# Altium schematic sheet 07_RELAY.SchDoc(07_RELAY)
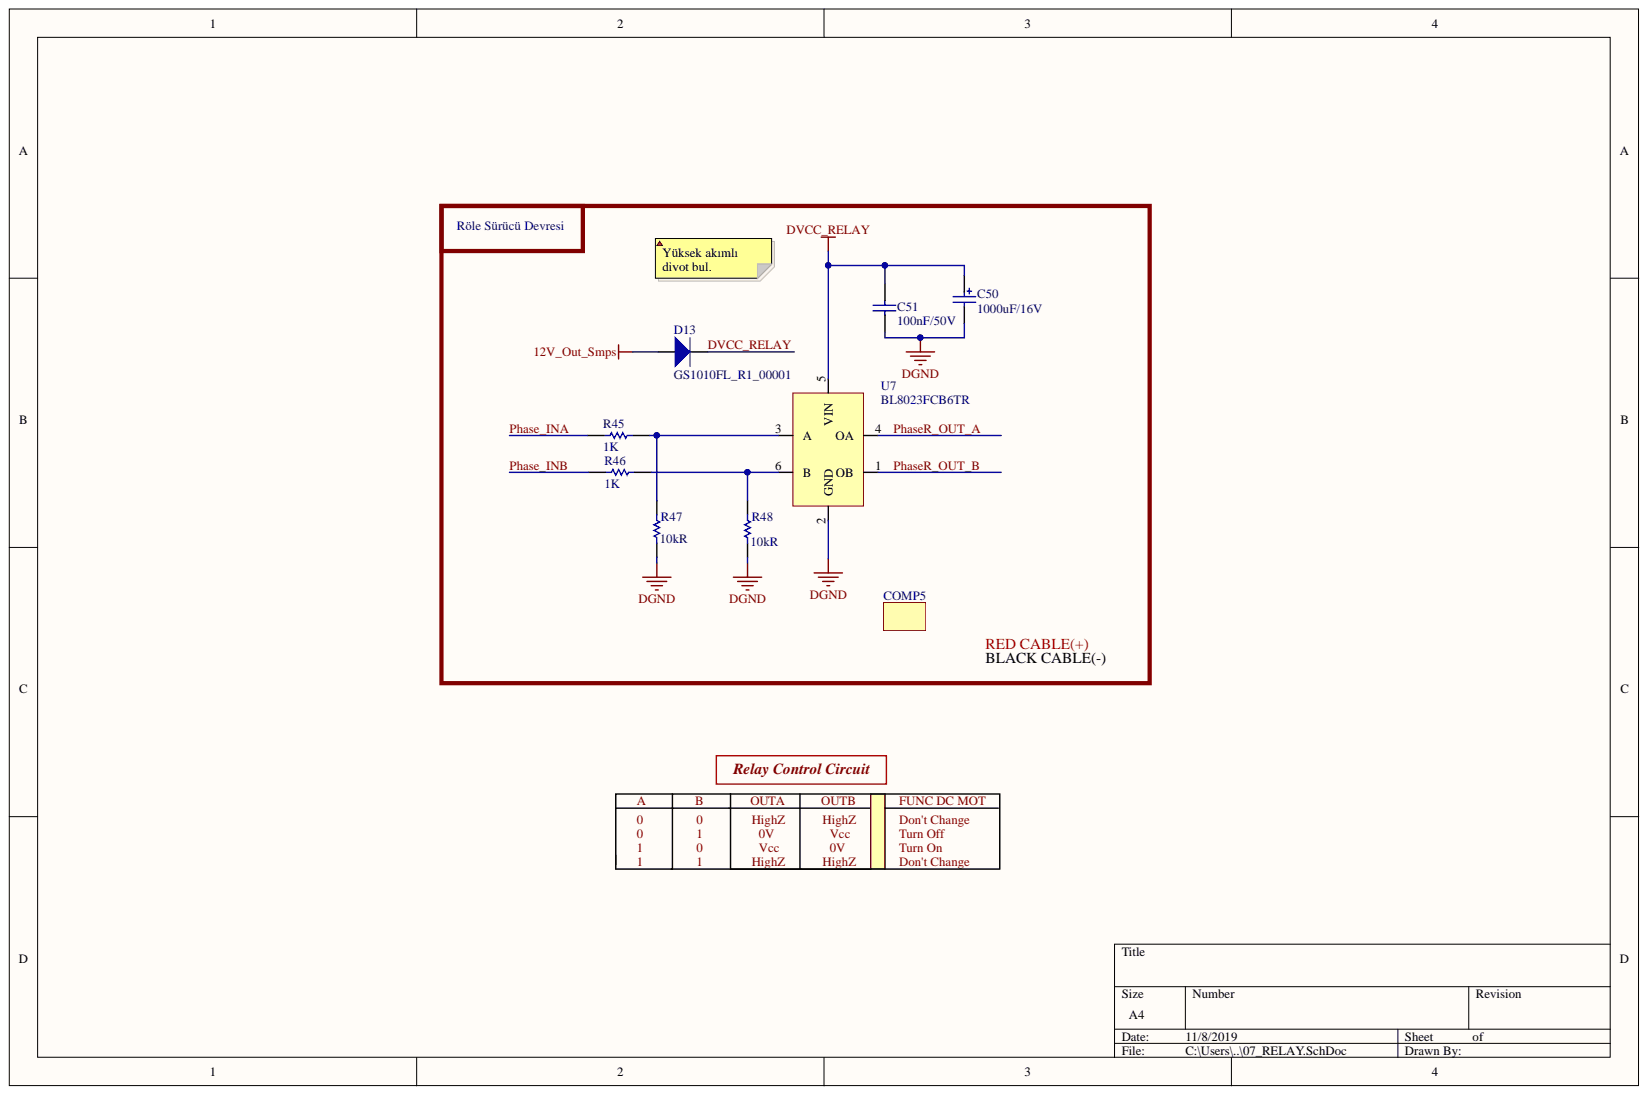
[diagram p0: 07_RELAY.SchDoc]

## Parts
C50  1000uF/16V  [CAP_ALU_ELC_RADIAL_D10_H16.5_P1-05.0_P2-05.0]
C51  100nF/50V  [CAP_0603_V1]
COMP5  PCB  (value not drawn)
D13  GS1010FL_R1_00001  [SOD123 - SOD323]
R45  1K  [RES_0603_V1]
R46  1K  [RES_0603_V1]
R47  Resistance: 10kR  [RES_0805_V1]  (hidden comment: RES_SMD)
R48  Resistance: 10kR  [RES_0805_V1]  (hidden comment: RES_SMD)
U7  BL8023FCB6TR  [SOT23-6]

## Nets
12V_Out_Smps: D13.1
DGND: C50.2 C51.1 R47.1 R48.1 U7.2(GND)
DVCC_RELAY: C50.1 C51.2 D13.2 U7.5(VIN)
Phase_INA: R45.1
Phase_INB: R46.1
PhaseR_OUT_A: U7.4(OA)
PhaseR_OUT_B: U7.1(OB)
NetR45_2: R45.2 R47.2 U7.3(A)
NetR46_2: R46.2 R48.2 U7.6(B)

## Library data of the parts
C50 = ERV1CM102G16OT: Aishi, Miniature Aliminium Electrolytic Capacitor; 048_Manufacturer-2 PN: WL1C108M10020PA; 047_Manufacturer-2: Samwha; 001_Description: Wl 1000UF 16V 20% 10X20/5, 0; Supplier Part Number 1: KEL16337; Supplier 1: Rutronik; Stock 1: 26000; Pricing 1: 500=0.09742, 1000=0.09292, 2000=0.08843, 2500=0.08168, 500=0.1093, 1000=0.1042, 2000=0.0992, 2500=0.0916 (EUR); Library Name: ElectricMeter.SchLib; Component Kind: Standard; 017_Manufacturer-1 PN: ERV1CM102G16OT; 016_Manufacturer-1: Aishi; 004_Value: 1000uF/16V
C51 = CL10B104KB8NNNC: MLCC; 100nF; 50V; X7R; ±10%; SMD; 0603; -55÷125°C; 016_Manufacturer-1: Samsung; Parameter Name: Tape and Reel; Material: Ceramic; 006_SMT/THT: Surface Mount; 001_Description: Capacitor: ceramic; MLCC; 100nF; 50V; X7R; ±10%; SMD; 0603; -55÷125°C; Width: 0.031inch; Voltage Rating (DC): 50V; Voltage Rating: 50V; Voltage: 50V; Tolerance: 10%; Thickness: 0.035inch; Supplier Part Number 2: CL10B104KB8NNNC; Supplier Part Number 1: CL10B104KB8NNNC; Supplier 2: Perfect Parts; Supplier 1: Arrow; Stock 2: 0; Stock 1: 16987458; Radiation Hardening: No; Pricing 1: 4000=0.0047, 8000=0.0043, 12000=0.0032, 1=0.0352, 10=0.0258, 25=0.0233, 100=0.02, 250=0.018 (USD); Package Quantity: 1; Mount: Surface Mount; Min Operating Temperature: -55°C; Max Operating Temperature: 125°C; Library Name: ElectricMeter.SchLib; Length: 1.6mm; Lead Free: Lead Free; Height: 0.8mm; Dielectric: X7R; Depth: 0.8mm; Contact Plating: Tin; ComponentLink3URL: https://produktinfo.conrad.com/datenblaetter/450000-474999/456651-da-01-en-VS_KONDENSATOR_0605.pdf?src-supplier=Conrad; ComponentLink3Description: https://produktinfo.conrad.com/datenblaetter/450000-474999/456651-da-01-en-VS_KONDENSATOR_0605.pdf?src-supplier=Conrad; ComponentLink2URL: https://www.verical.com/server-webapp/html/getDatasheet?partID=89097&src-supplier=Verical; ComponentLink2Description: https://www.verical.com/server-webapp/html/getDatasheet?partID=89097&src-supplier=Verical; ComponentLink1URL: http://www.samsungsem.com/kr/support/product-search/mlcc/CL10B104KB8NNNC.jsp?src-supplier=Digi-Key; ComponentLink1Description: http://www.samsungsem.com/kr/support/product-search/mlcc/CL10B104KB8NNNC.jsp?src-supplier=Digi-Key; Component Kind: Standard; Case Code (Metric): 1608; Case Code (Imperial): 0603; Case/Package: 0603; Capacitance: 100nF; 017_Manufacturer-1 PN: CL10B104KB8NNNC
COMP5 = 00_BOS: BOLTA, BDJ011-120A-009 DC Motor Relay, Red Cable will connect to pin 1 and black cable will connect to pin 2.; Library Name: SCH_LIB.SCHLIB; Component Kind: Standard; 017_Manufacturer-1 PN: BDJ011-120A-009; 016_Manufacturer-1: BOLTA
D13 = DIODE_SMD: DIODE STAND. Single 1A 1000V SMT SOD123FL; 016_Manufacturer-1: Pan Jit; Peak Reverse Current: 1uA; Peak Non-Repetitive Surge Current: 16.5A; Max Repetitive Reverse Voltage (Vrrm): 1kV; 006_SMT/THT: Surface Mount; Supplier Part Number 1: GS1010FL _R1 _00001; Supplier 1: NAC Semi; Stock 1: 295000; Radiation Hardening: No; Pricing 1: 24000=0.0296, 295000=0.0276 (USD); Number of Pins: 2; Min Operating Temperature: -50°C; Max Operating Temperature: 150°C; Library Name: ElectricMeter.SchLib; Forward Current: 1A; Element Configuration: Single; Component Kind: Standard; 017_Manufacturer-1 PN: GS1010FL_R1_00001
R45 R46 = RC0603FR-071KL: RES SMD 1K OHM 1% 1/10W 0603; 016_Manufacturer-1: Yageo; Voltage Rating: 50V; Temperature Coefficient: 100ppm/°C; Resistance: 1kR; Power Rating: 100mW; Parameter Name: Tape and Reel; Tolerance: 1%; Termination: SMD/SMT; Supplier Part Number 1: RC0603FR-07 1KL; Supplier 1: Verical; Stock 1: 0; REACH SVHC: No SVHC; Radiation Hardening: No; Min Operating Temperature: -55°C; Military Standard: Not; Max Operating Temperature: 155°C; Library Name: ElectricMeter.SchLib; Length: 1.6mm; Lead Free: Lead Free; Height: 0.45mm; Depth: 0.8mm; Contact Plating: Matte,Tin; Composition: Thick Film; ComponentLink7URL: http://www.farnell.com/datasheets/443971.pdf?src-supplier=Element14; ComponentLink7Description: http://www.farnell.com/datasheets/443971.pdf?src-supplier=Element14; ComponentLink6URL: https://www.verical.com/server-webapp/html/getDatasheet?partID=404327&src-supplier=Verical; ComponentLink6Description: https://www.verical.com/server-webapp/html/getDatasheet?partID=404327&src-supplier=Verical; ComponentLink5URL: http://www.yageo.com/documents/recent/PYu-RC_Group_51_RoHS_L_2.pdf?src-supplier=Avnet; ComponentLink5Description: http://www.yageo.com/documents/recent/PYu-RC_Group_51_RoHS_L_2.pdf?src-supplier=Avnet; ComponentLink4URL: http://www.farnell.com/datasheets/1868787.pdf?src-supplier=Element14; ComponentLink4Description: http://www.farnell.com/datasheets/1868787.pdf?src-supplier=Element14; ComponentLink3URL: http://www.farnell.com/datasheets/2167052.pdf?src-supplier=Element14; ComponentLink3Description: http://www.farnell.com/datasheets/2167052.pdf?src-supplier=Element14; ComponentLink2URL: http://www.yageo.com/documents/recent/PYu-RC0603_51_RoHS_L_4.pdf?src-supplier=Avnet+Europe; ComponentLink2Description: http://www.yageo.com/documents/recent/PYu-RC0603_51_RoHS_L_4.pdf?src-supplier=Avnet+Europe; ComponentLink1URL: http://documents.rs-components.com/techlib://itc_doclist_GB.htm?stockno=5048928&src-supplier=RSComponents; ComponentLink1Description: http://documents.rs-components.com/techlib://itc_doclist_GB.htm?stockno=5048928&src-supplier=RSComponents; Component Kind: Standard; Case Code (Metric): 1608; Case Code (Imperial): 0603; Case/Package: 0603; 017_Manufacturer-1 PN: RC0603FR-071KL
R47 R48 = RES_SMD: 017_Manufacturer-1 PN: RC0805FR-0710KL; 016_Manufacturer-1: Yageo; Voltage Rating: 150V; Tolerance: 1%; Temperature Coefficient: 100ppm/°C; Power Rating: 125mW; Parameter Name: Tape and Reel; 001_Description: RES SMD 10K OHM 1% 1/8W 0805; Width: 0.049inch; Termination: SMD/SMT; Supplier Part Number 1: RC0805FR-0710KL; Supplier 1: STLAA.com; Stock 1: 0; REACH SVHC: Unknown; Package Quantity: 1; Number of Terminations: 2; Min Operating Temperature: -55°C; Military Standard: Not; Max Power Dissipation: 125mW; Max Operating Temperature: 155°C; Library Name: ElectricMeter.SchLib; Length: 2mm; Lead Free: Lead Free; Height: 0.024inch; Features: Moisture Resistant; Depth: 1.25mm; Composition: Thick Film; ComponentLink7URL: http://www.yageo.ru/pdf/RC0805.pdf?src-supplier=Future+Electronics; ComponentLink7Description: http://www.yageo.ru/pdf/RC0805.pdf?src-supplier=Future+Electronics; ComponentLink6URL: http://www.yageo.com/documents/recent/PYu-RC_Group_51_RoHS_L_9.pdf?src-supplier=Mouser; ComponentLink6Description: http://www.yageo.com/documents/recent/PYu-RC_Group_51_RoHS_L_9.pdf?src-supplier=Mouser; ComponentLink5URL: http://www.mouser.com/ds/2/447/RC0805-257173.pdf?src-supplier=Mouser; ComponentLink5Description: http://www.mouser.com/ds/2/447/RC0805-257173.pdf?src-supplier=Mouser; ComponentLink4URL: http://www.farnell.com/datasheets/444164.pdf?src-supplier=Element14; ComponentLink4Description: http://www.farnell.com/datasheets/444164.pdf?src-supplier=Element14; ComponentLink3URL: https://www.verical.com/server-webapp/html/getDatasheet?partID=327895&src-supplier=Verical; ComponentLink3Description: https://www.verical.com/server-webapp/html/getDatasheet?partID=327895&src-supplier=Verical; ComponentLink2URL: http://www.yageo.com/documents/recent/PYu-RC_Group_51_RoHS_L_9.pdf?src-supplier=Digi-Key; ComponentLink2Description: http://www.yageo.com/documents/recent/PYu-RC_Group_51_RoHS_L_9.pdf?src-supplier=Digi-Key; ComponentLink1URL: http://www.yageo.com/documents/recent/PYu-RC_Group_51_RoHS_L_10.pdf?src-supplier=Digi-Key; ComponentLink1Description: http://www.yageo.com/documents/recent/PYu-RC_Group_51_RoHS_L_10.pdf?src-supplier=Digi-Key; Component Kind: Standard; Case Code (Metric): 2012; Case Code (Imperial): 0805; Case/Package: 0805
U7 = BL8023FCB6TR: 300mA Bi-Direction Relay Driver; 016_Manufacturer-1: Belling; Library Name: ElectricMeter.SchLib; Component Kind: Standard; 017_Manufacturer-1 PN: BL8023FCB6TR
